# Altium schematic sheet USB_HUB.SchDoc(USB_HUB)
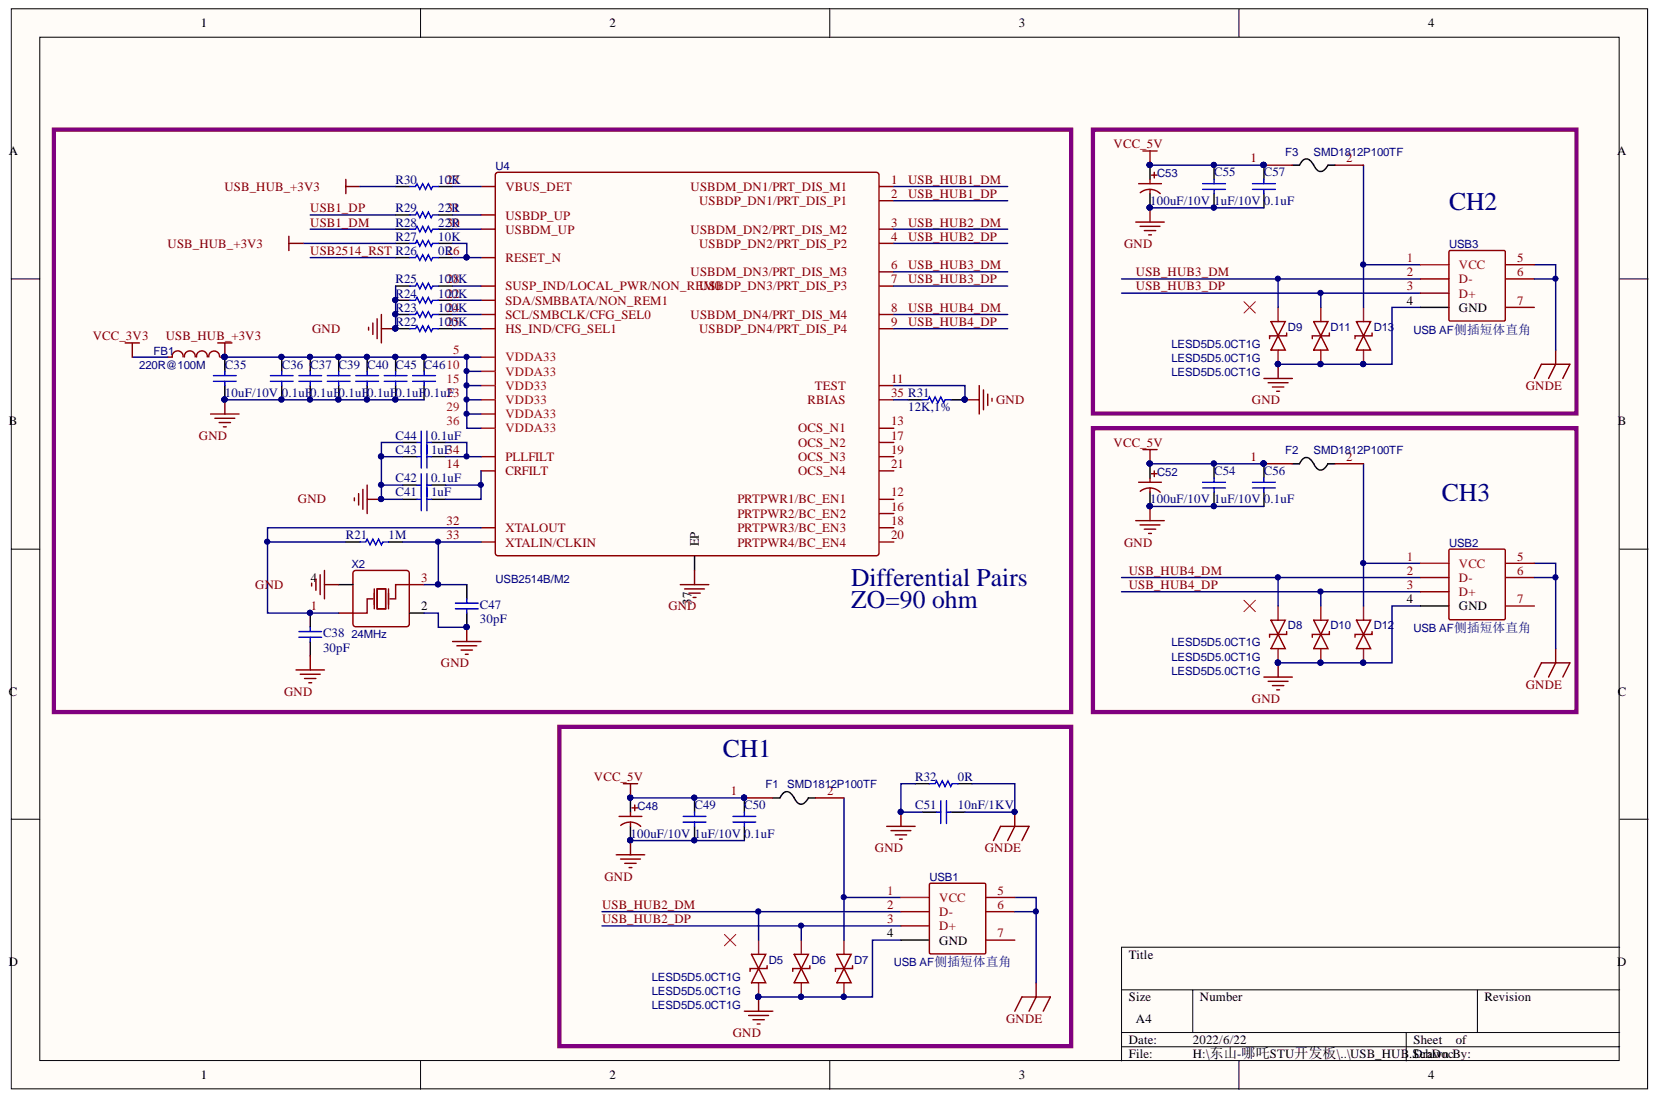
[diagram p0: USB_HUB.SchDoc]

## Parts
C35  10uF/10V  [0603]
C36  0.1uF  [0402]
C37  0.1uF  [0402]
C38  30pF  [0402]
C39  0.1uF  [0402]
C40  0.1uF  [0402]
C41  1uF  [0402]
C42  0.1uF  [0402]
C43  1uF  [0402]
C44  0.1uF  [0402]
C45  0.1uF  [0402]
C46  0.1uF  [0402]
C47  30pF  [0402]
C48  100uF/10V  [CAP-SMD_BD5.0-L5.3-W5.3-FD]
C49  1uF/10V  [0402]
C50  0.1uF  [0402]
C51  10nF/1KV  [1206C]
C52  100uF/10V  [CAP-SMD_BD5.0-L5.3-W5.3-FD]
C53  100uF/10V  [CAP-SMD_BD5.0-L5.3-W5.3-FD]
C54  1uF/10V  [0402]
C55  1uF/10V  [0402]
C56  0.1uF  [0402]
C57  0.1uF  [0402]
D5  LESD5D5.0CT1G  [SOD-523_L1.2-W0.8-LS1.6-BI]
D6  LESD5D5.0CT1G  [SOD-523_L1.2-W0.8-LS1.6-BI]
D7  LESD5D5.0CT1G  [SOD-523_L1.2-W0.8-LS1.6-BI]
D8  LESD5D5.0CT1G  [SOD-523_L1.2-W0.8-LS1.6-BI]
D9  LESD5D5.0CT1G  [SOD-523_L1.2-W0.8-LS1.6-BI]
D10  LESD5D5.0CT1G  [SOD-523_L1.2-W0.8-LS1.6-BI]
D11  LESD5D5.0CT1G  [SOD-523_L1.2-W0.8-LS1.6-BI]
D12  LESD5D5.0CT1G  [SOD-523_L1.2-W0.8-LS1.6-BI]
D13  LESD5D5.0CT1G  [SOD-523_L1.2-W0.8-LS1.6-BI]
F1  SMD1812P100TF  [F1812]
F2  SMD1812P100TF  [F1812]
F3  SMD1812P100TF  [F1812]
FB1  220R@100M  [L0603]
R21  1M  [0402]
R22  100K  [0402]
R23  100K  [0402]
R24  100K  [0402]
R25  100K  [0402]
R26  0R  [0402]
R27  10K  [0402]
R28  22R  [0402]
R29  22R  [0402]
R30  10K  [0402]
R31  12K,1%  [0402]
R32  0R  [1206R]
U4  USB2514B/M2  [SQFN-36_L6.0-W6.0-P0.50-BL-EP]
USB1  USB AF侧插短体直角  [USB-A-TH_4P-P1.4]
USB2  USB AF侧插短体直角  [USB-A-TH_4P-P1.4]
USB3  USB AF侧插短体直角  [USB-A-TH_4P-P1.4]
X2  24MHz  [OSC-SMD_4P-L3.2-W2.5-BL]

## Nets
GND: C35.1 C36.1 C37.1 C38.1 C39.1 C40.1 C41.1 C42.1 C43.1 C44.1 C45.1 C46.1 C47.1 C48.2 C49.1 C50.2 C51.1 C52.2 C53.2 C54.1 C55.1 C56.2 C57.2 D5.1 D6.1 D7.1 D8.1 D9.1 D10.1 D11.1 D12.1 D13.1 R22.2 R23.2 R24.2 R25.2 R31.2 R32.1 U4.11 U4.37(EP) USB1.4(GND) USB2.4(GND) USB3.4(GND) X2.2 X2.4
GNDE: C51.2 R32.2 USB1.5 USB1.6 USB2.5 USB2.6 USB3.5 USB3.6
USB_HUB_+3V3: C35.2 C36.2 C37.2 C39.2 C40.2 C45.2 C46.2 FB1.2 R27.2 R30.2 U4.5(VDDA33) U4.10(VDDA33) U4.15(VDD33) U4.23(VDD33) U4.29(VDDA33) U4.36(VDDA33)
VCC_3V3: FB1.1
VCC_5V: C48.1 C49.2 C50.1 C52.1 C53.1 C54.2 C55.2 C56.1 C57.1 F1.1 F2.1 F3.1
USB1_DM: R28.2
USB1_DP: R29.2
USB2514_RST: R26.2
USB_HUB1_DM: U4.1
USB_HUB1_DP: U4.2
USB_HUB2_DM: D5.2 U4.3 USB1.2(D-)
USB_HUB2_DP: D6.2 U4.4 USB1.3(D+)
USB_HUB3_DM: D9.2 U4.6 USB3.2(D-)
USB_HUB3_DP: D11.2 U4.7 USB3.3(D+)
USB_HUB4_DM: D8.2 U4.8 USB2.2(D-)
USB_HUB4_DP: D10.2 U4.9 USB2.3(D+)
NetC38_2: C38.2 R21.2 U4.32(XTALOUT) X2.1
NetC41_2: C41.2 C42.2 U4.14(CRFILT)
NetC43_2: C43.2 C44.2 U4.34(PLLFILT)
NetC47_2: C47.2 R21.1 U4.33(XTALIN/CLKIN) X2.3
NetD7_2: D7.2 F1.2 USB1.1(VCC)
NetD12_2: D12.2 F2.2 USB2.1(VCC)
NetD13_2: D13.2 F3.2 USB3.1(VCC)
NetR22_1: R22.1 U4.25(HS_IND/CFG_SEL1)
NetR23_1: R23.1 U4.24(SCL/SMBCLK/CFG_SEL0)
NetR24_1: R24.1 U4.22(SDA/SMBBATA/NON_REM1)
NetR25_1: R25.1 U4.28(SUSP_IND/LOCAL_PWR/NON_REM0)
NetR26_1: R26.1 R27.1 U4.26(RESET_N)
NetR28_1: R28.1 U4.30(USBDM_UP)
NetR29_1: R29.1 U4.31(USBDP_UP)
NetR30_1: R30.1 U4.27(VBUS_DET)
NetR31_1: R31.1 U4.35

Not connected: U4 12 13 16 17 18 19 20 21; USB1 7; USB2 7; USB3 7

## Library data of the parts
C35-C47 C49-C51 C54-C57 = Cap: Capacitor; PartNumber: CAP100NF; Component Kind: Standard; LatestRevisionDate: 17-Jul-2002; LatestRevisionNote: Re-released for DXP Platform.; Library Name: Miscellaneous Devices.IntLib; PackageReference: 0402; Published: 8-Jun-2000; Signal Integrity: Cap; Simulation: CAP; Publisher: Altium Limited
  C35: Value: 10uF/10V
  C36 C37 C39 C40 C42 C44-C46 C50 C56 C57: Value: 0.1uF
  C38 C47: Value: 30pF
  C41 C43: Value: 1uF
  C49 C54 C55: Value: 1uF/10V
  C51: Value: 10nF/1KV
C48 C52 C53 = 100uF: BOM_Manufacturer: ReliaPro; nameAlias: Capacitance; BOM_Supplier: LCSC; BOM_Supplier Part: C13376; Component Kind: Standard; spicePre: C; Value: 100uF/10V
D5-D13 = LESD5D5.0CT1G: BOM_Manufacturer: Leshan Radio; Contributor: LCSC; BOM_JLCPCB Part Class: Extended Part; BOM_Manufacturer Part: LESD5D5.0CT1G; BOM_Supplier: LCSC; BOM_Supplier Part: C383211; Component Kind: Standard; spicePre: D; spiceSymbolName: LESD5D5.0CT1G
F1-F3 = SMD1812P014TF: BOM_Manufacturer: RUILON; BOM_JLCPCB Part Class: Extended Part; BOM_Supplier: LCSC; Component Kind: Standard; Library Name: HM2.SchLib; spicePre: F
FB1 = (no symbol name): BOM_Manufacturer: MuRata; BOM_Manufacturer Part: BLM18KG221SN1D; nameAlias: Value; BOM_JLCPCB Part Class: Extended Part; BOM_Supplier: LCSC; BOM_Supplier Part: C88980; Component Kind: Standard; spicePre: L; spiceSymbolName: BLM18KG221SN1D
R21-R31 = Res1: Resistor; PartNumber: RES2.2K; Component Kind: Standard; LatestRevisionDate: 17-Jul-2002; LatestRevisionNote: Re-released for DXP Platform.; Library Name: Miscellaneous Devices.IntLib; PackageReference: 0402; Published: 8-Jun-2000; Signal Integrity: Res; Simulation: RESISTOR; Publisher: Altium Limited
  R21: Value: 1M
  R22-R25: Value: 100K
  R26: Value: 0R
  R27 R30: Value: 10K
  R28 R29: Value: 22R
  R31: Value: 12K,1%
R32 = Res1: Resistor; PartNumber: RES22R; Component Kind: Standard; LatestRevisionDate: 17-Jul-2002; LatestRevisionNote: Re-released for DXP Platform.; Library Name: Miscellaneous Devices.IntLib; PackageReference: 0402; Published: 8-Jun-2000; Signal Integrity: Res; Simulation: RESISTOR; Value: 0R; Publisher: Altium Limited
U4 = USB2514B/M2: BOM_Manufacturer: Microchip Tech; Contributor: LCSC; BOM_JLCPCB Part Class: Extended Part; BOM_Manufacturer Part: USB2514B/M2; BOM_Supplier: LCSC; BOM_Supplier Part: C220807; Component Kind: Standard; Library Name: HM2.SchLib; spicePre: U; spiceSymbolName: USB2514B/M2
USB1-USB3 = USB_AF_P1.4: BOM_Manufacturer: 深圳凯拓林电子; BOM_Manufacturer Part: USB AF侧插短体直角; Component Kind: Standard; Library Name: HM2.SchLib
X2 = 24MHz_1: BOM_Manufacturer: JGHC; BOM_Manufacturer Part: S3224000151050; Contributor: LCSC; nameAlias: Frequency; BOM_Supplier: LCSC; BOM_Supplier Part: C426976; Component Kind: Standard; Library Name: HM2.SchLib; spicePre: X; spiceSymbolName: S3224000151050
